# Altium schematic sheet Ethernet_1.SchDoc
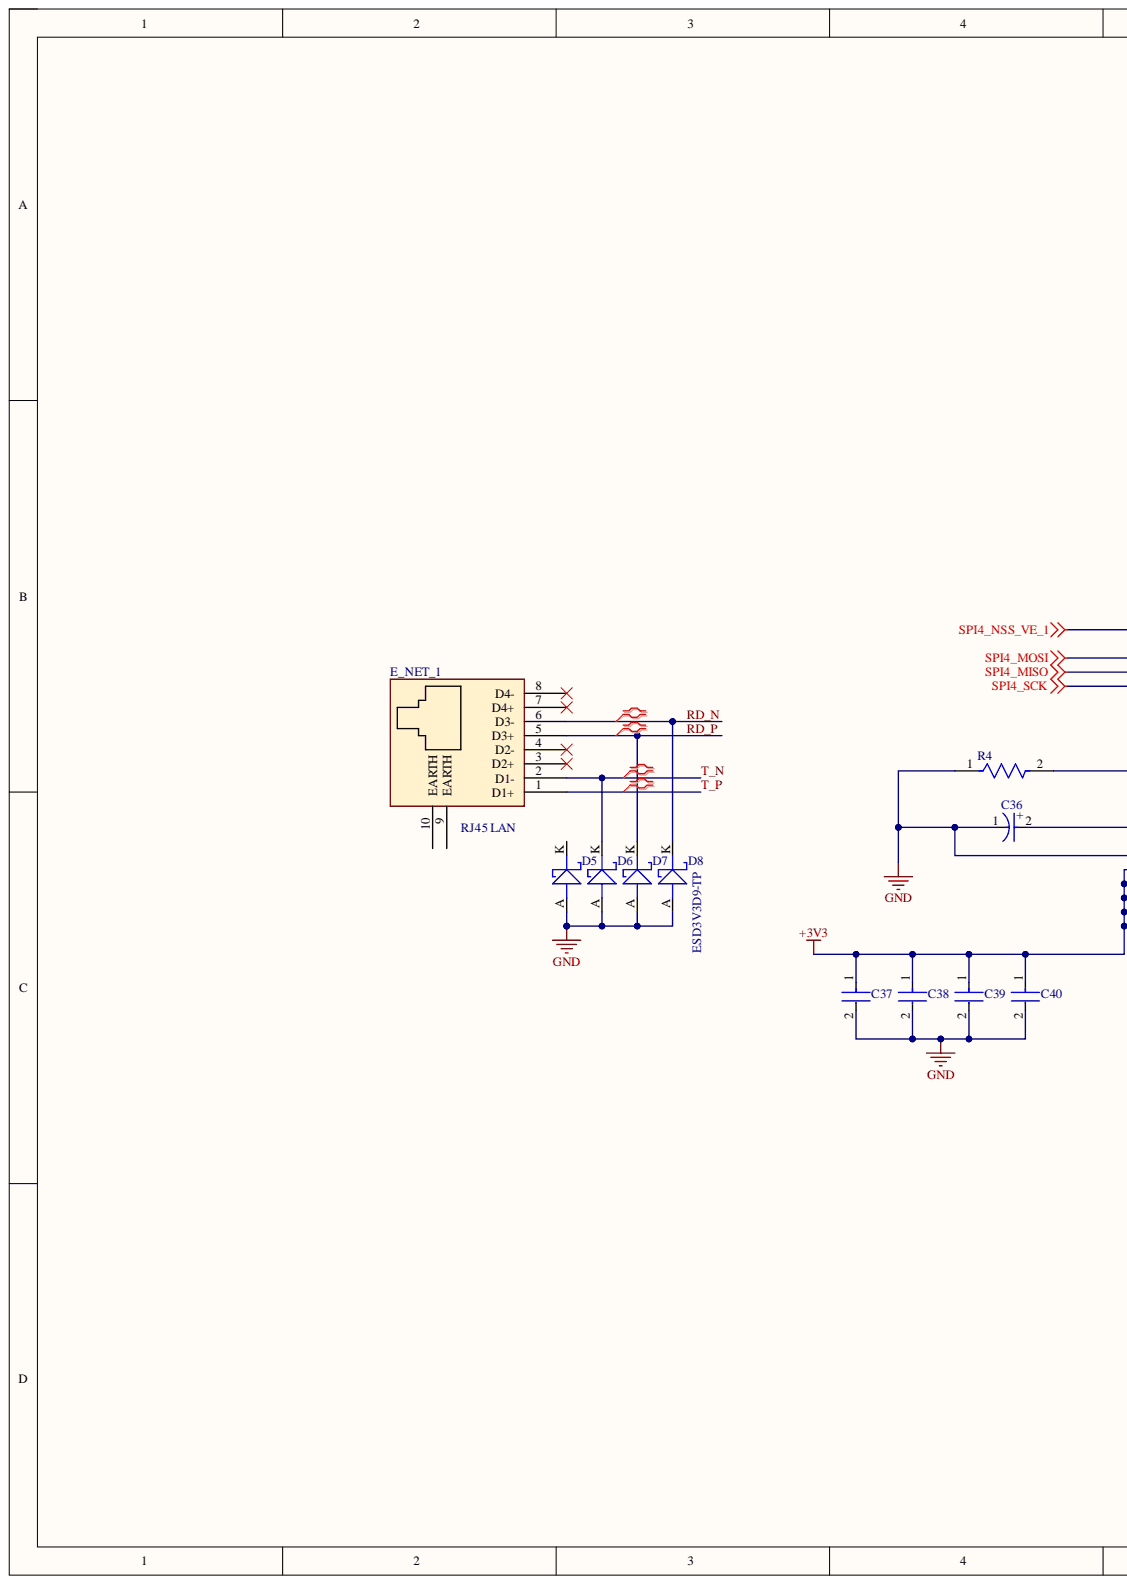
[diagram p0: Ethernet_1.SchDoc, left]
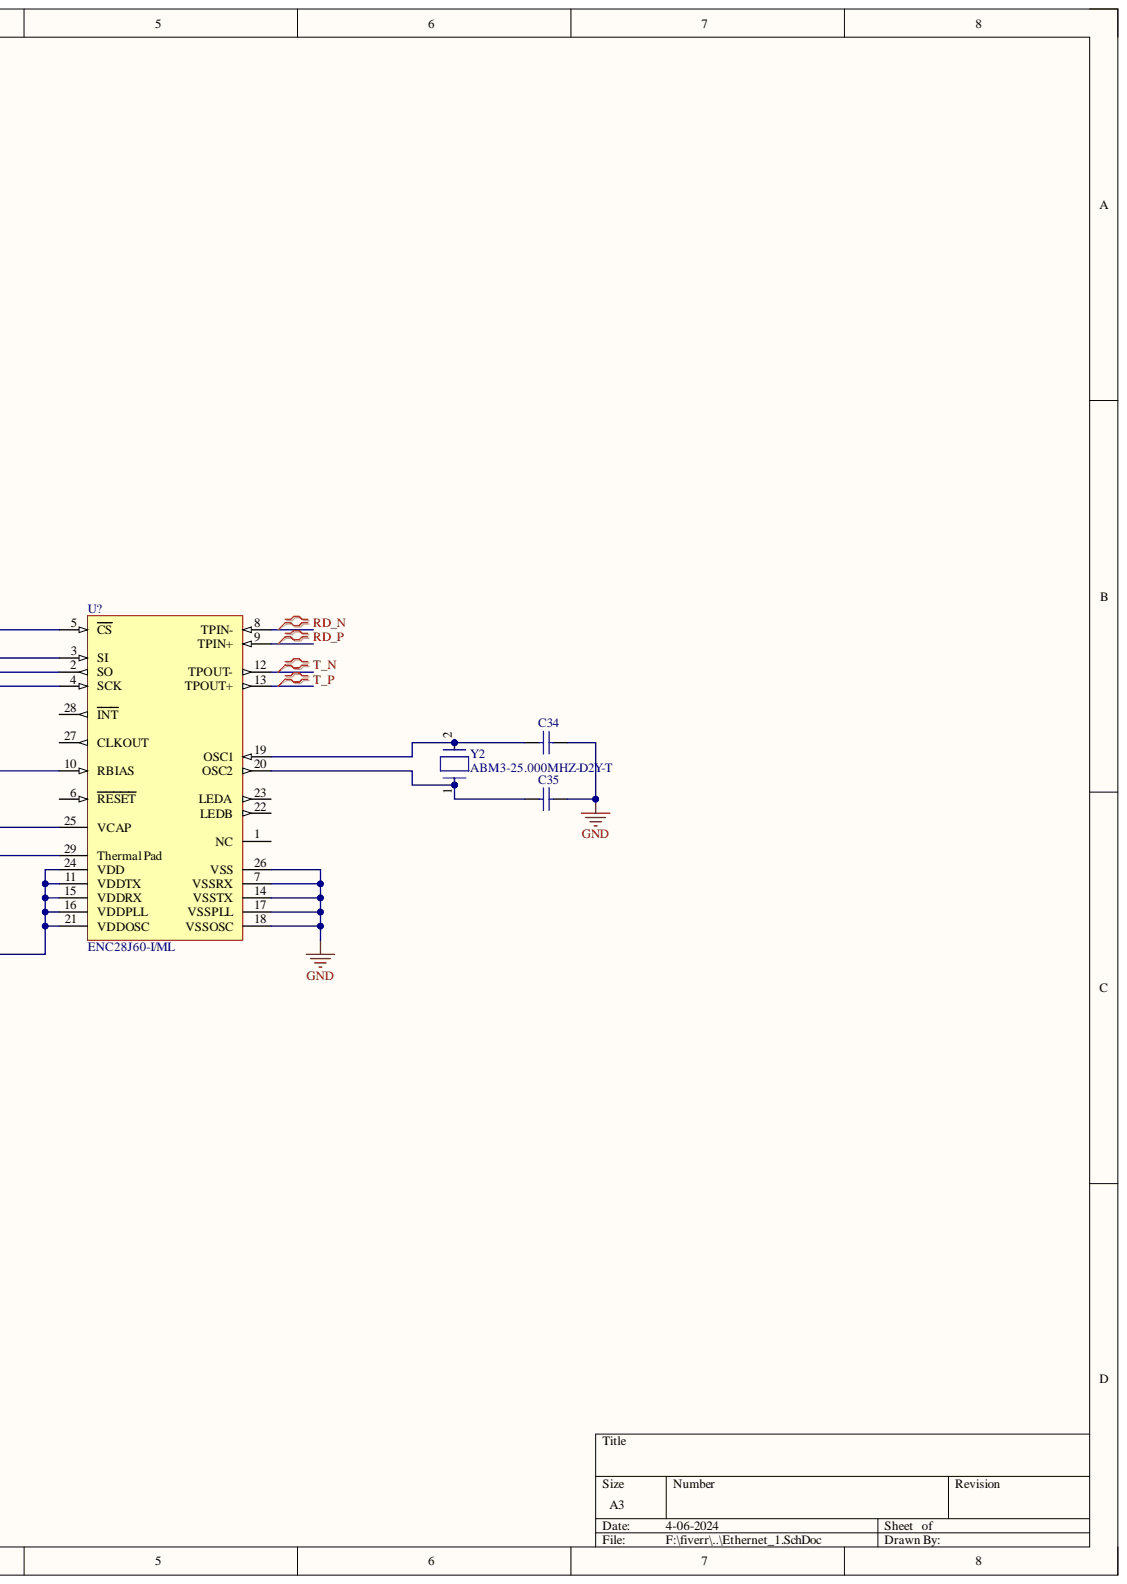
[diagram p1: Ethernet_1.SchDoc, right]

## Parts
C34  CC0603JRNPO9BN180  [CAPC1608X90X40LL10T20]  (value not drawn)
C35  CC0603JRNPO9BN180  [CAPC1608X90X40LL10T20]  (value not drawn)
C36  593D106X9016A2TE3  [FP-593DA-MFG]  (value not drawn)
C37  C0402C104K8RACTU  [FP-C0402-BB-MFG]  (value not drawn)
C38  C0402C104K8RACTU  [FP-C0402-BB-MFG]  (value not drawn)
C39  C0402C104K8RACTU  [FP-C0402-BB-MFG]  (value not drawn)
C40  C0402C104K8RACTU  [FP-C0402-BB-MFG]  (value not drawn)
D5  ESD3V3D9-TP  [DIO_SOD-923]
D6  ESD3V3D9-TP  [DIO_SOD-923]
D7  ESD3V3D9-TP  [DIO_SOD-923]
D8  ESD3V3D9-TP  [DIO_SOD-923]
E_NET_1  RJ45 LAN  [CON10_P106_RJ45_TH_ST]
R4  ERJ-2RKF2001X  [FP-ERJ2RK-MFG]  (value not drawn)
U?  ENC28J60-I/ML  [QFN-ML28_M]
Y2  ABM3-25.000MHZ-D2Y-T  [FP-ABM3-MFG]

## Nets
+3V3: C37.1 C38.1 C39.1 C40.1 U?.11(VDDTX) U?.15(VDDRX) U?.16(VDDPLL) U?.21(VDDOSC) U?.24(VDD)
GND: C34.1 C35.1 C36.1 C37.2 C38.2 C39.2 C40.2 D5.A D6.A D7.A D8.A R4.1 U?.7(VSSRX) U?.14(VSSTX) U?.17(VSSPLL) U?.18(VSSOSC) U?.26(VSS) U?.29(Thermal_Pad)
SPI4_MISO: U?.2(SO)
SPI4_MOSI: U?.3(SI)
SPI4_NSS_VE_1: U?.5(CS)
SPI4_SCK: U?.4(SCK)
RD_N: D8.K E_NET_1.6(D3-) U?.8(TPIN-)
RD_P: D7.K E_NET_1.5(D3+) U?.9(TPIN+)
T_N: D6.K E_NET_1.2(D1-) U?.12(TPOUT-)
T_P: E_NET_1.1(D1+) U?.13(TPOUT+)
NetC34_2: C34.2 U?.19(OSC1) Y2.2
NetC35_2: C35.2 U?.20(OSC2) Y2.1
NetC36_2: C36.2 U?.25(VCAP)
NetR4_2: R4.2 U?.10(RBIAS)

Not connected: D5 K; E_NET_1 3(D2+) 4(D2-) 7(D4+) 8(D4-) 9(EARTH) 10(EARTH); U? 1(NC) 6(RESET) 22(LEDB) 23(LEDA) 27(CLKOUT) 28(INT)

## Library data of the parts
C34 C35 = CMP-1659-00030-1: Chip Capacitor, 18 pF, +/- 5%, 50 V, -55 to 125 degC, 0603 (1608 Metric), RoHS, Tape and Reel; Case\Package: 0603; Power Rating: 100 mW; Resistance: 68.1 Ohm; Tolerance: 1%; Voltage Rating: 50 V; Case Code (Imperial): 0603; Case Code (Metric): 1608; Component Kind: Standard; ComponentLink1Description: Manufacturer URL; ComponentLink1URL: http://www.yageo.com/; ComponentLink2Description: Datasheet; ComponentLink2URL: http://www.yageo.com/NewPortal/yageodocoutput?fileName=/pdf/MLCC/UPY-GP_NP0_16V-to-50V_14.pdf; DatasheetVersion: Rev. 14, 07/2016; Height: 0.45 mm; Length: 1.6 mm; Library Name: Altium Content Vault; Max Operating Temperature: 155 degC; Min Operating Temperature: -55 degC; Package Quantity: 200; PackageDescription: 2-Pin Surface Mount Device, Body 1.6 x 0.8 mm; PackageReference: 0603; Packaging: Tape and Reel; Radiation Hardening: No; RoHSCompliant: true; Width: 0.8 mm
C36 = CMP-00030-39455466-1: 593D106X9016A2TE3 Cap Tant Solid 10uF 16V A CASE 10% (3.2 X 1.6 X 1.6mm) SMD 3216-18 1.7 Ohm 125C T/R; Capacitance: 10µF; Dissipation Factor: 6%; ESR (Equivalent Series Resistance): 1.7Ω; Leakage Current: 1.6µA; Polarity: Polar; Ripple Current: 210µA; Case Code (Imperial): 1206; Case Code (Metric): 3216; Case/Package: 1206; Component Kind: Standard; Depth: 1.6mm; Features: General Purpose; Height: 1.6mm; Height - Seated (Max): 1.8034mm; Lead Free: Lead Free; Length: 3.2mm; Library Name: Altium Content Vault; Max Operating Temperature: 125°C; Min Operating Temperature: -55°C; Mount: PCB, Surface Mount; NexarPartId: 39455466; Packaging: Cut Tape; Radiation Hardening: No; REACH SVHC: Unknown; RoHS: Compliant; Schedule B: 8532210050, 8532210050; Termination: SMD/SMT; Tolerance: 10%; Voltage: 16V; Voltage Rating: 16V; Voltage Rating (DC): 16V; Width: 1.6mm
C37-C40 = CMP-2008-03086-2: CAP CER 0.1UF 10V X7R 0402; Manufacturer: Kemet; Capacitance: 0.10 μF; Material: Ceramic; Temperature Coefficient: 15%; Tolerance: 0.1; Value: 0.10 μF; Automotive: No; Category: Cap; Component Kind: Standard; ComponentLink2Description: Datasheet; ComponentLink2URL: https://content.kemet.com/datasheets/KEM_C1002_X7R_SMD.pdf; Device Class L1: Passive Components; Device Class L2: Capacitors; Device Class L3: Ceramic Capacitors; Digikey Description: CAP CER 0.1UF 10V X7R 0402; Height: 0.55mm; Ipc Land Pattern Name: CAPC10050X50; Lead Free: Yes; Library Name: Altium Content Vault; Manufacturer Part Number: C0402C104K8RACTU; Mouser Description: Multilayer Ceramic Capacitors MLCC - SMD/SMT 10volts 0.1uF X7R 10%; Octopart: https://octopart.com/c0402c104k8ractu-kemet-106349; Package: C0402-BB; RoHS: TRUE; Temperature: -55°C to +125°C; Temperature Characteristic: X7R; Temperature Range High: +125°C; Temperature Range Low: -55°C; Voltage Rating: 10 V
D5-D8 = DIO-T_3.3V-8.8V-SOD923: 8.8V Clamp 5A (8/20µs) Ipp Tvs Diode Surface Mount SOD-923; Alternate Part Number-1: NA; Manufacturer: Micro Commercial Co; Case/Package: SOD923; Component Kind: Standard; Library Name: ESTEEMPCBLIB.SchLib; Manufacturer Part Number: ESD3V3D9-TP; Supplier Part Number-1: ESD3V3D9-TPMSCT-ND - Cut Tape (CT); Supplier-1: Digikey
E_NET_1 = CON-SKT-RJ_8C8P-RA-TH: 8 Pins, 2 Row 2.54mm Pitch, right angle Connector, male; Alternate Part Number-1: NA; Manufacturer: Assmann; Manufacturer Part Number: A-2004-2-4-LPS-N-R; Case/Package: TH-CON; Component Kind: Standard; Library Name: ESTEEMPCBLIB.IntLib; Supplier Part Number-1: A-2004-2-4-LPS-N-R; Supplier-1: SP ROAD
R4 = CMP-2002-00758-4: RES SMD 2K OHM 1% 1/10W 0402; Max Power Dissipation: 100mW; Resistance: 2kR; Temperature Coefficient: 100ppm/°C; Voltage Rating: 50V; Case Code (Imperial): 0402; Case Code (Metric): 1005; Case/Package: 0402; Component Kind: Standard; Construction: Rectangular; Contact Plating: Tin; Depth: 0.5mm; Features: Automotive AEC-Q200; Height: 0.35mm; Lead Free: Lead Free; Length: 1mm; Library Name: Altium Content Vault; Max Operating Temperature: 155°C; Military Standard: Not; Min Operating Temperature: -55°C; Mount: Surface Mount; Number of Terminations: 2; Package Quantity: 10000; Packaging: Tape and Reel; Power Rating: 100mW; Radiation Hardening: No; REACH SVHC: No SVHC; Resistance Tolerance: 1%; Resistor Type: Thick Film; RoHS Compliant: Yes; Series: ERJ; Technology: THICK FILM; Termination: SMD/SMT; Tolerance: 1%; Voltage Rating (DC): 50V; Width: 0.02inch; Working Voltage: 50V
U? = CMP-0186-00028-2: Stand-Alone Ethernet Controller with SPI Interface, 28-Pin QFN, Industrial Temperature; Code_JEDEC: MO-220; Component Kind: Standard; ComponentLink1Description: Manufacturer URL; ComponentLink1URL: http://www.microchip.com/; ComponentLink2Description: Datasheet; ComponentLink2URL: http://ww1.microchip.com/downloads/en/DeviceDoc/39662c.pdf; ComponentLink3Description: Package Specification; ComponentLink3URL: http://www.microchip.com/stellent/groups/techpub_sg/documents/packagingspec/en012702.pdf; DatasheetVersion: revC, Jan-2008; Library Name: Altium Content Vault; PackageDescription: 28-Lead Plastic Quad Flat, No Lead Package (ML) - 6x6 mm Body [QFN] with 0.55 mm Contact Length; PackageReference: QFN-ML28; PackageVersion: revBB, Aug-2009; RevisionNote: URLs added.
Y2 = CMP-27762-002203-1: Crystal 25MHz ±20ppm 18pF SMD-2 5mm x 3.2mm; ESR (Equivalent Series Resistance): 30R; Frequency Stability: 30ppm; Case/Package: CSMD; Component Kind: Standard; Depth: 3.2mm; Frequency: 25MHz; Frequency Tolerance: 20ppm; Height: 0.051inch; Lead Free: Lead Free; Length: 5mm; Library Name: Altium Content Vault; Load Capacitance: 18pF; Max Operating Temperature: 85°C; Min Operating Temperature: -40°C; Mount: Surface Mount; Number of Pins: 2; Operating Frequency: 25 MHz; Package Quantity: 1000; Packaging: Tape and Reel; REACH SVHC: Yes; Resistor Type: Ceramic; Series: ABM3; Series Resistance: 30R; Termination: SMD/SMT; Tolerance: 20ppm; Width: 3.2mm
